# Altium schematic sheet Sheet1.SchDoc(Sheet1)
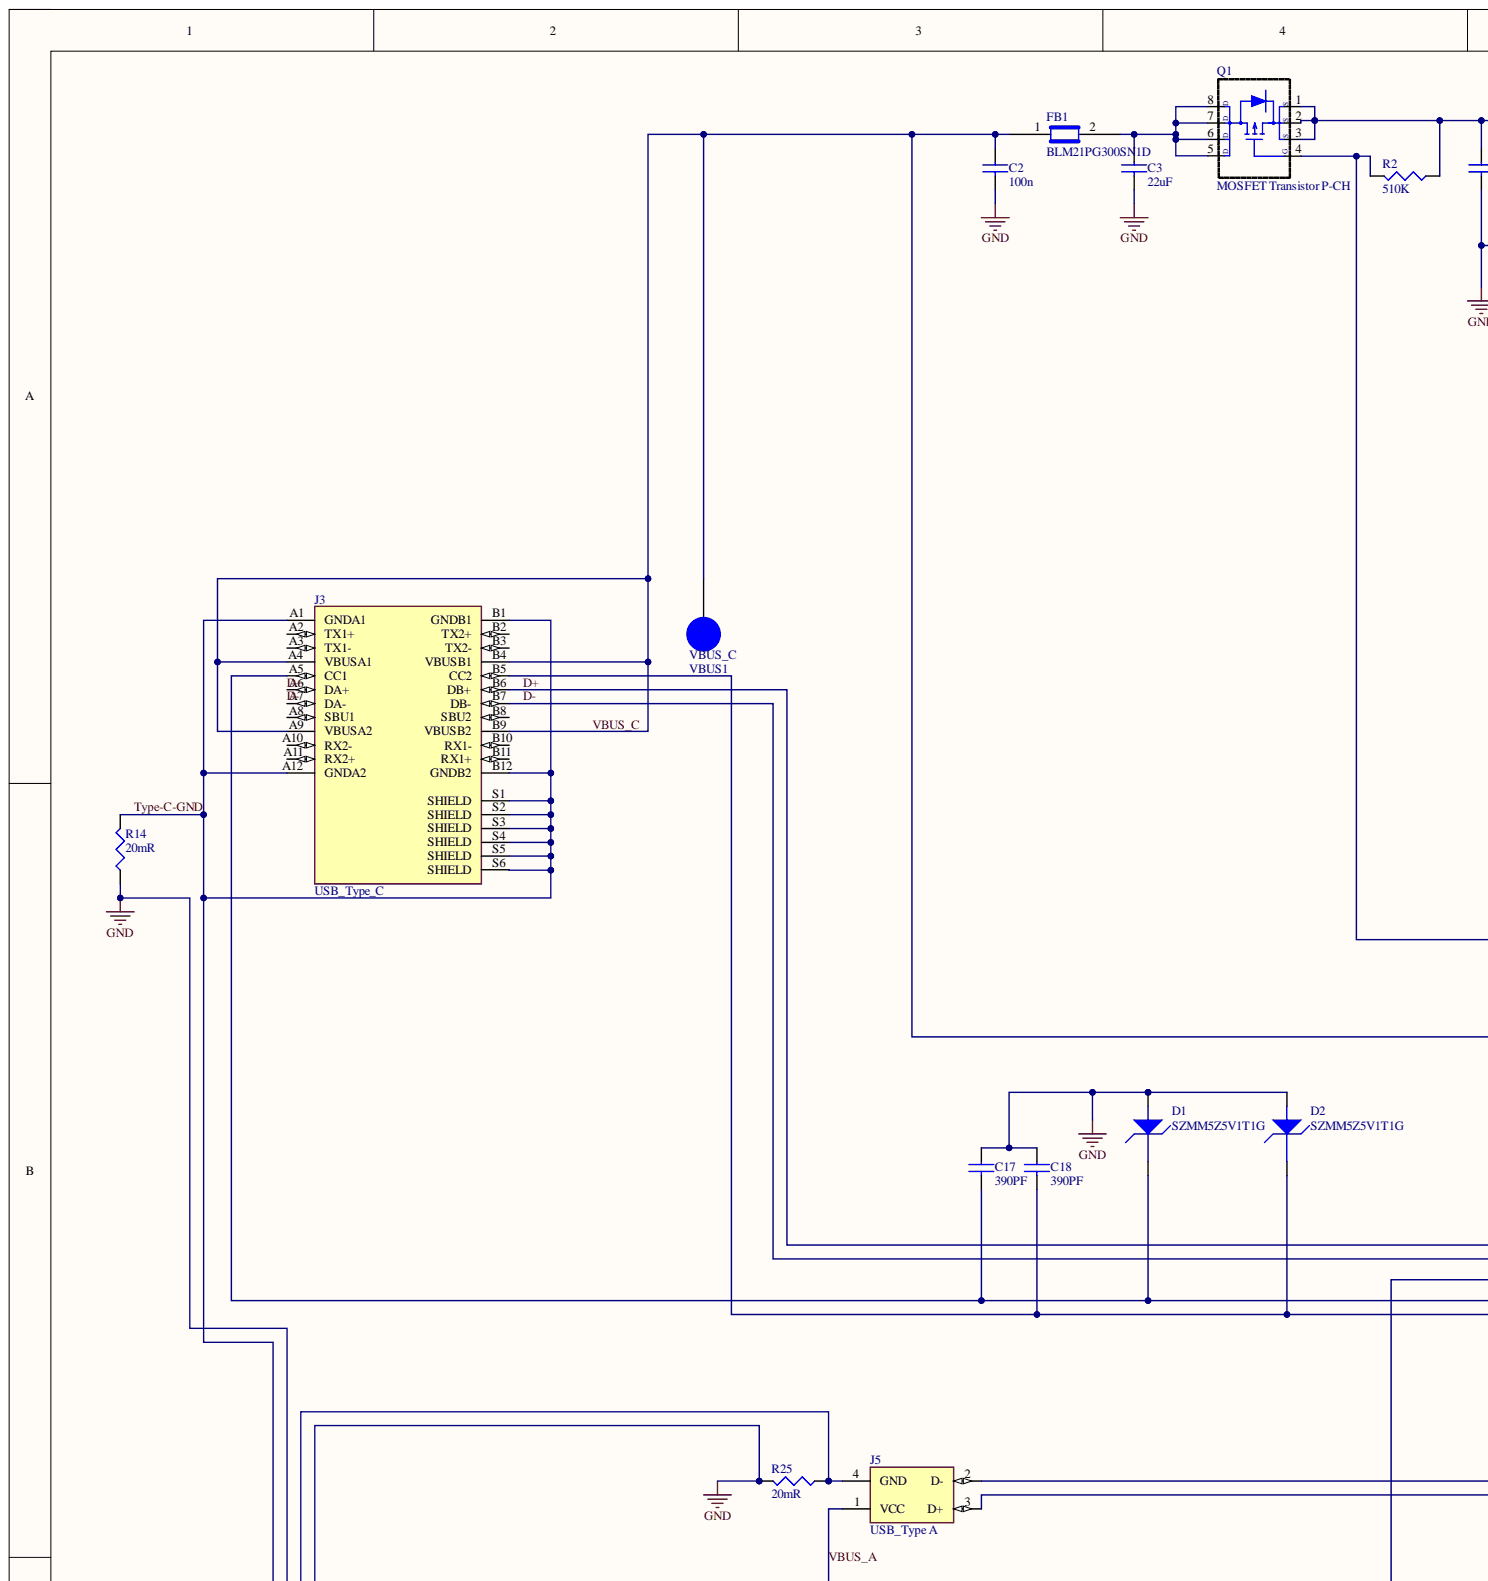
[diagram p0: Sheet1.SchDoc, top left]
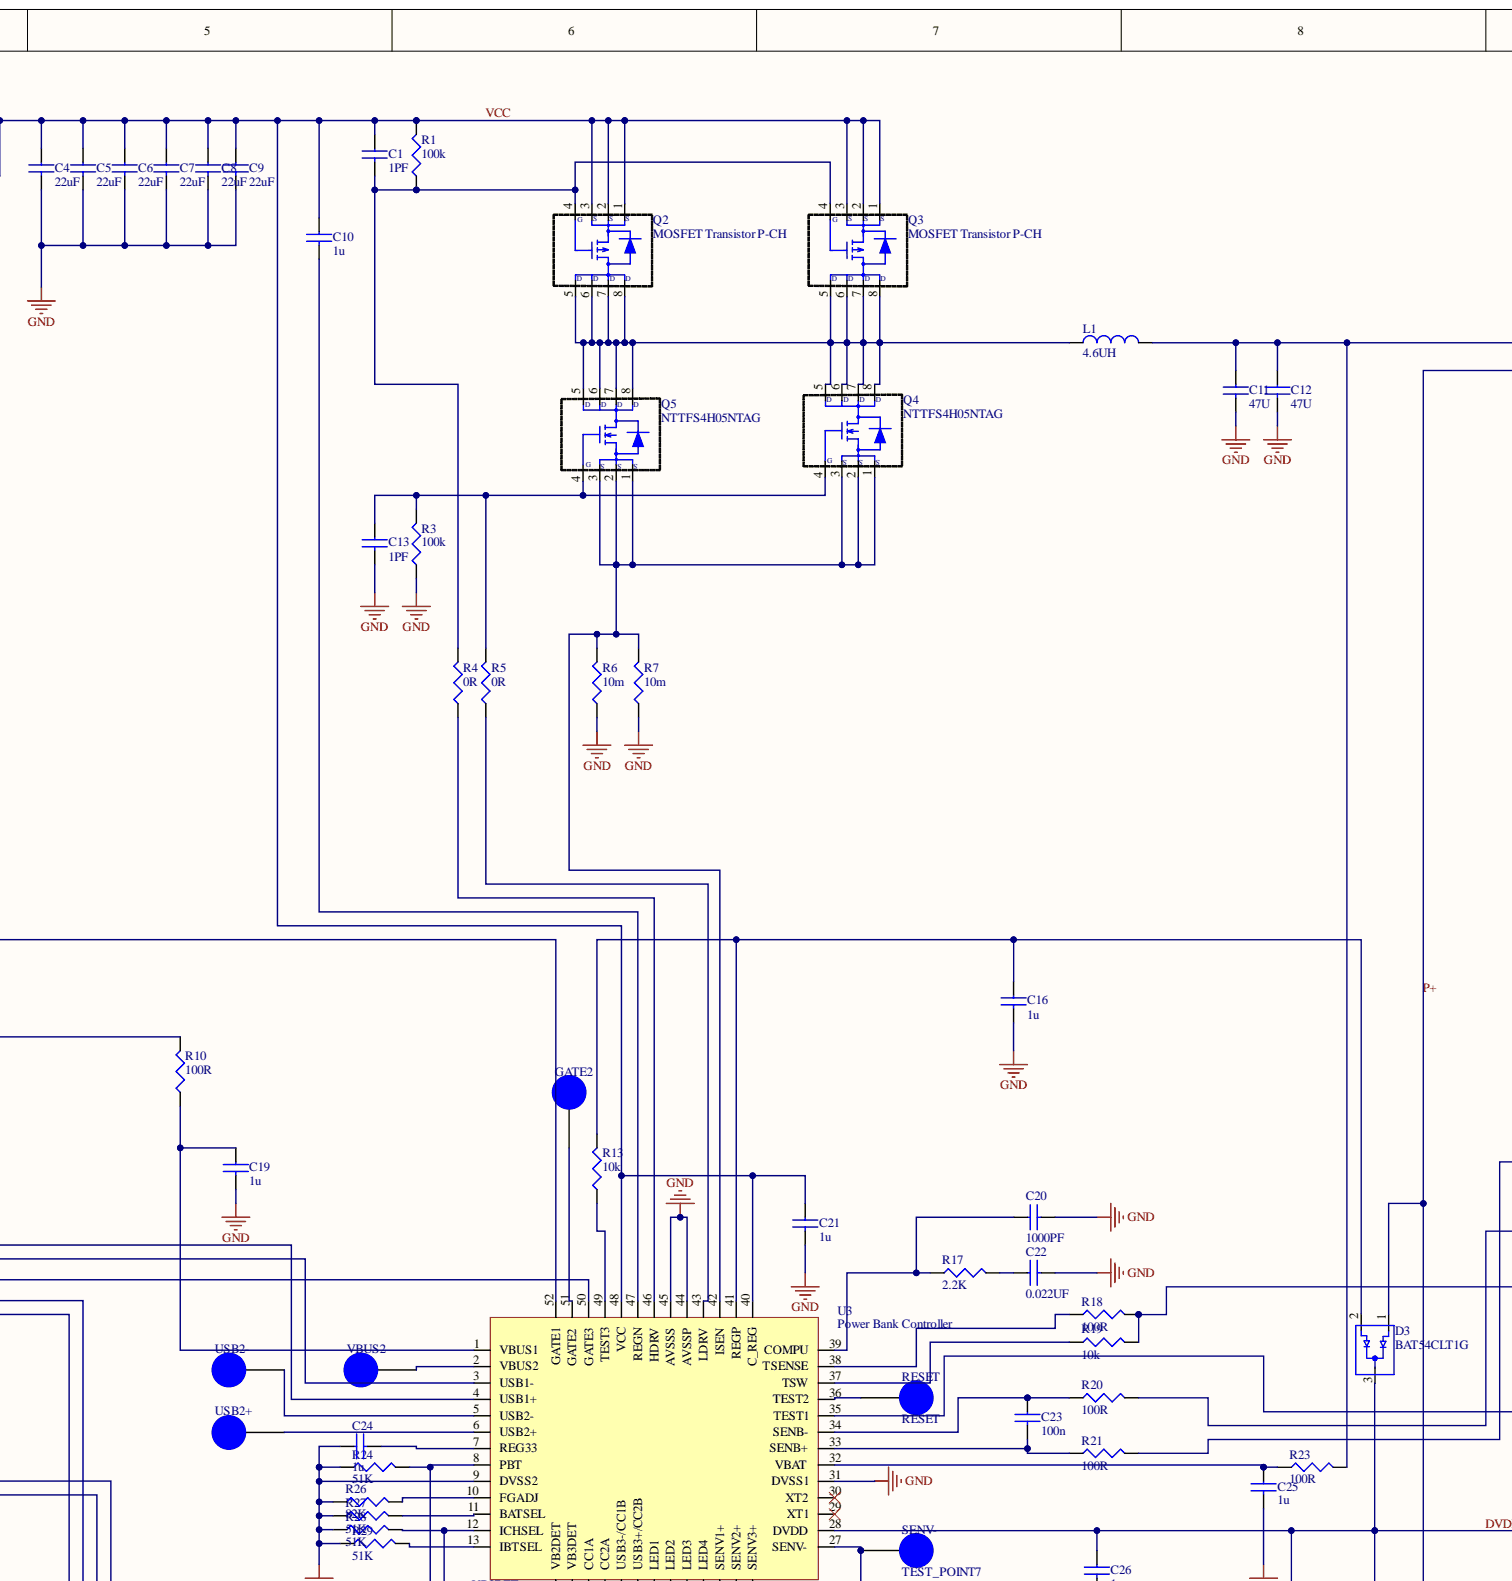
[diagram p1: Sheet1.SchDoc, top centre]
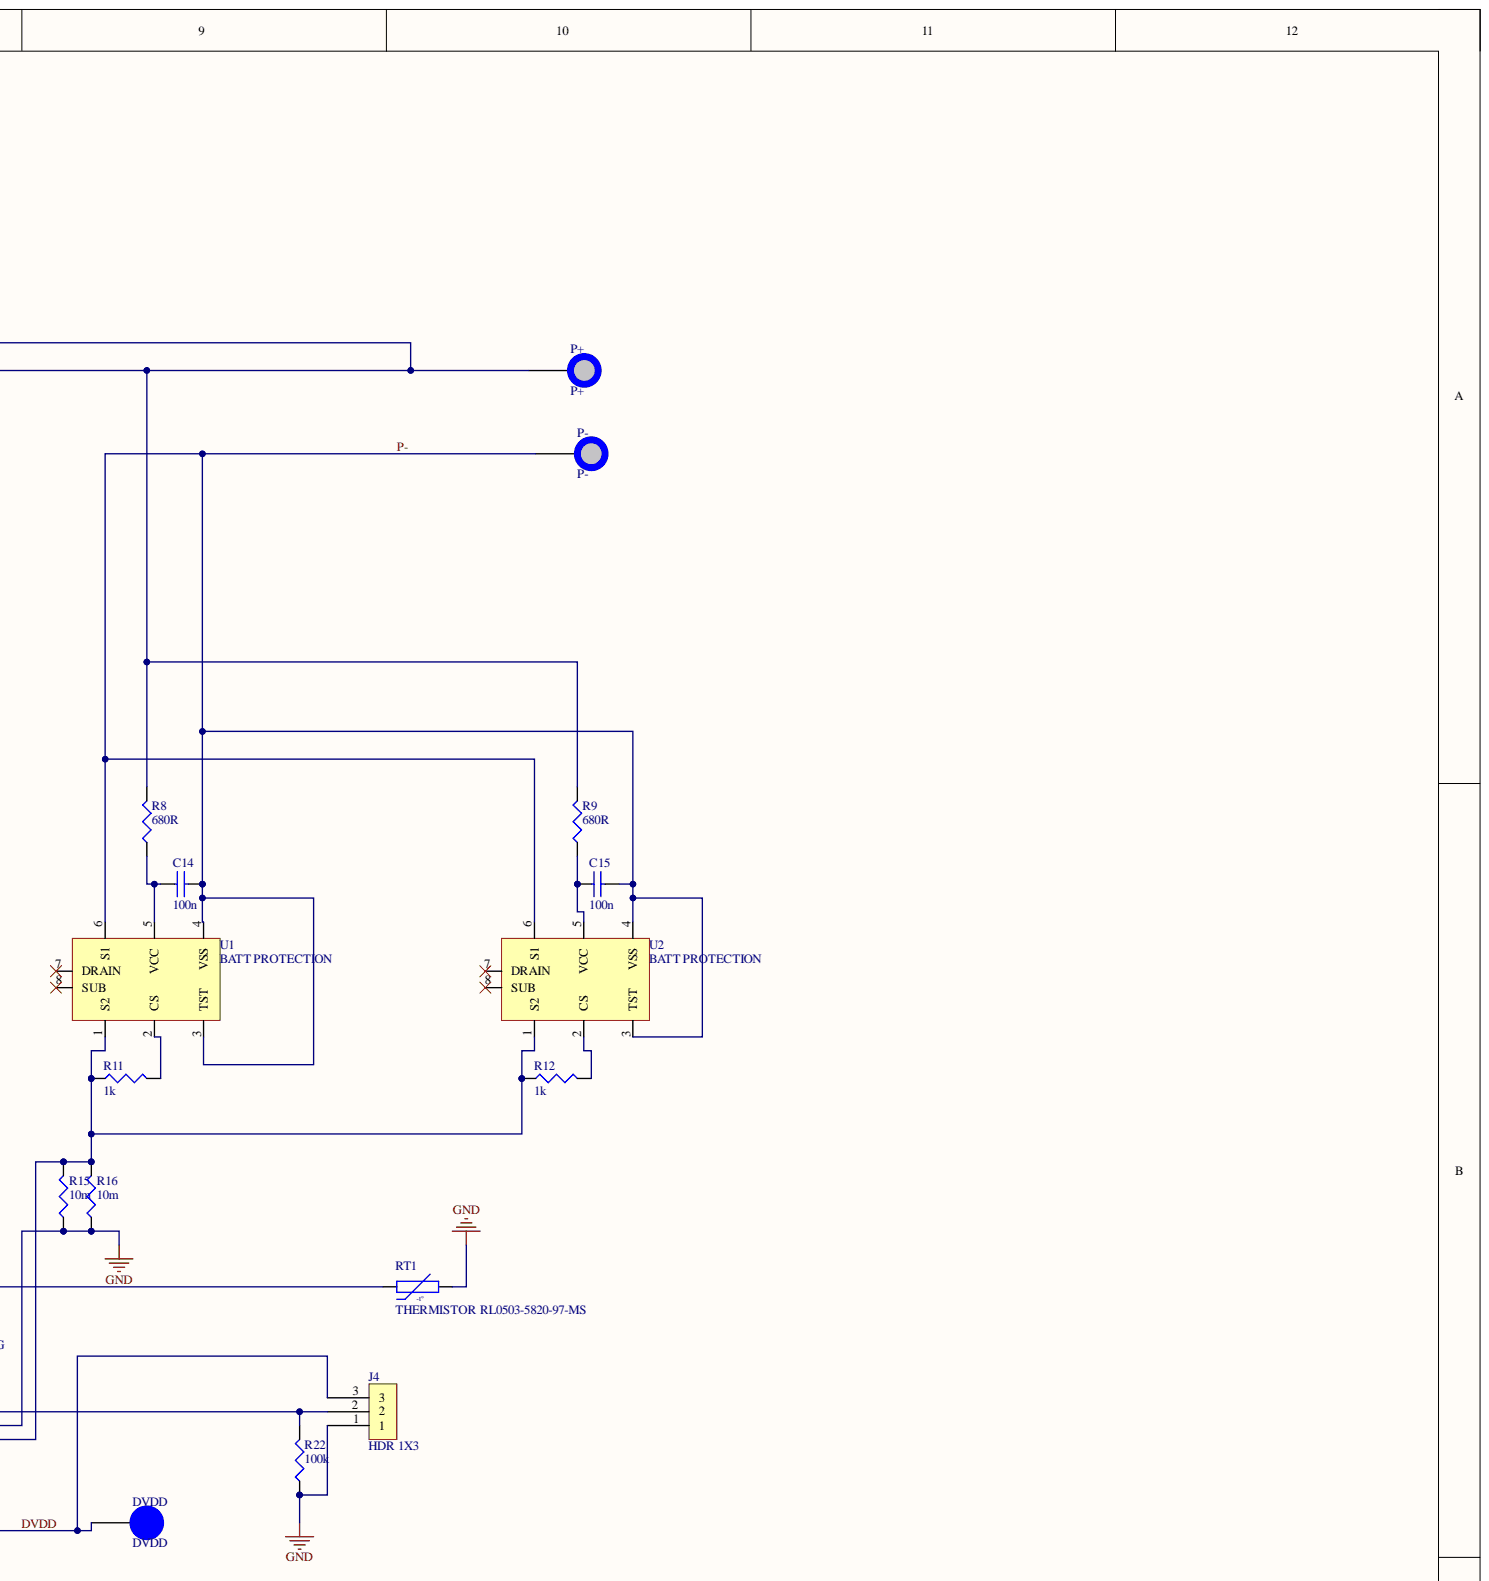
[diagram p2: Sheet1.SchDoc, top right]
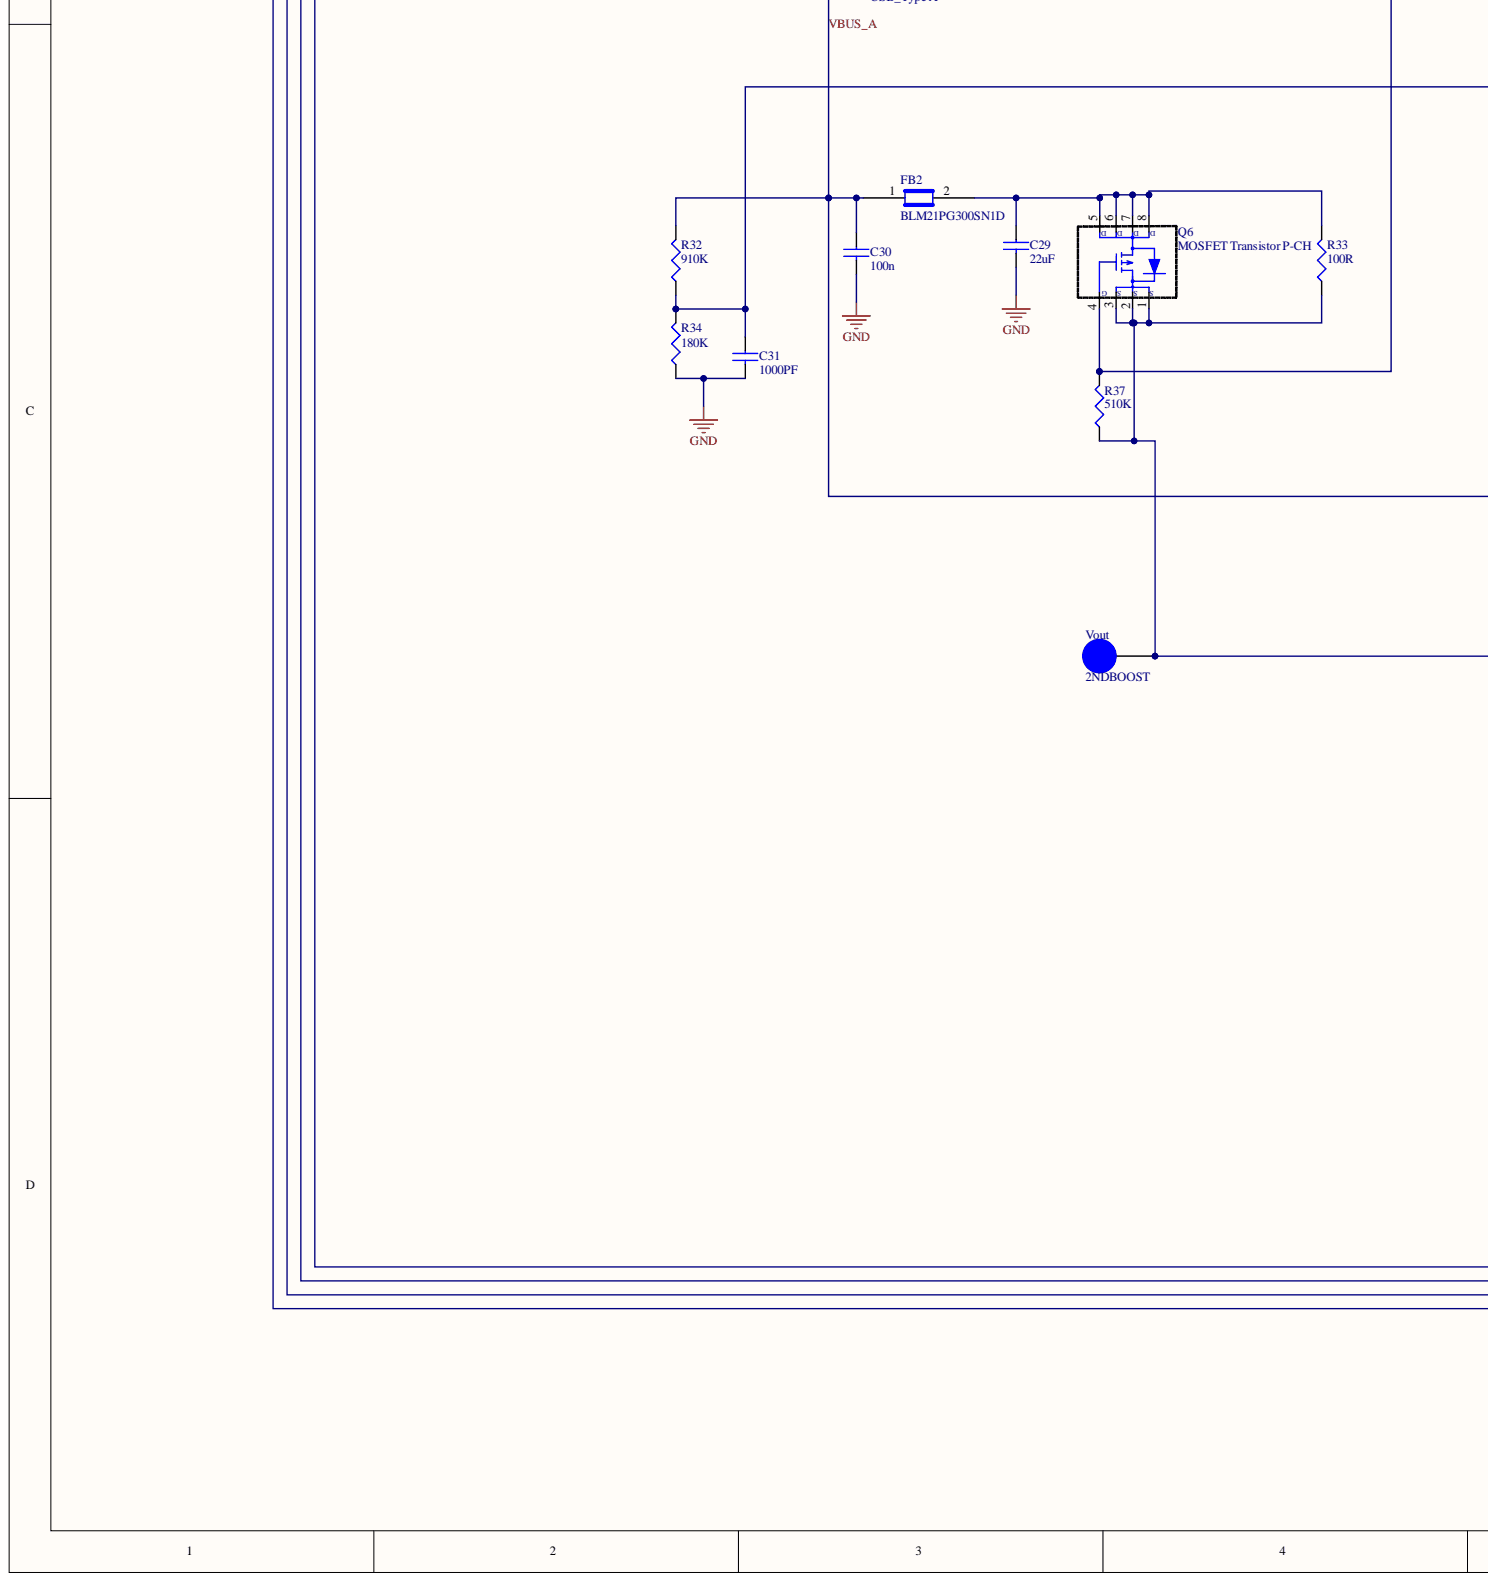
[diagram p3: Sheet1.SchDoc, bottom left]
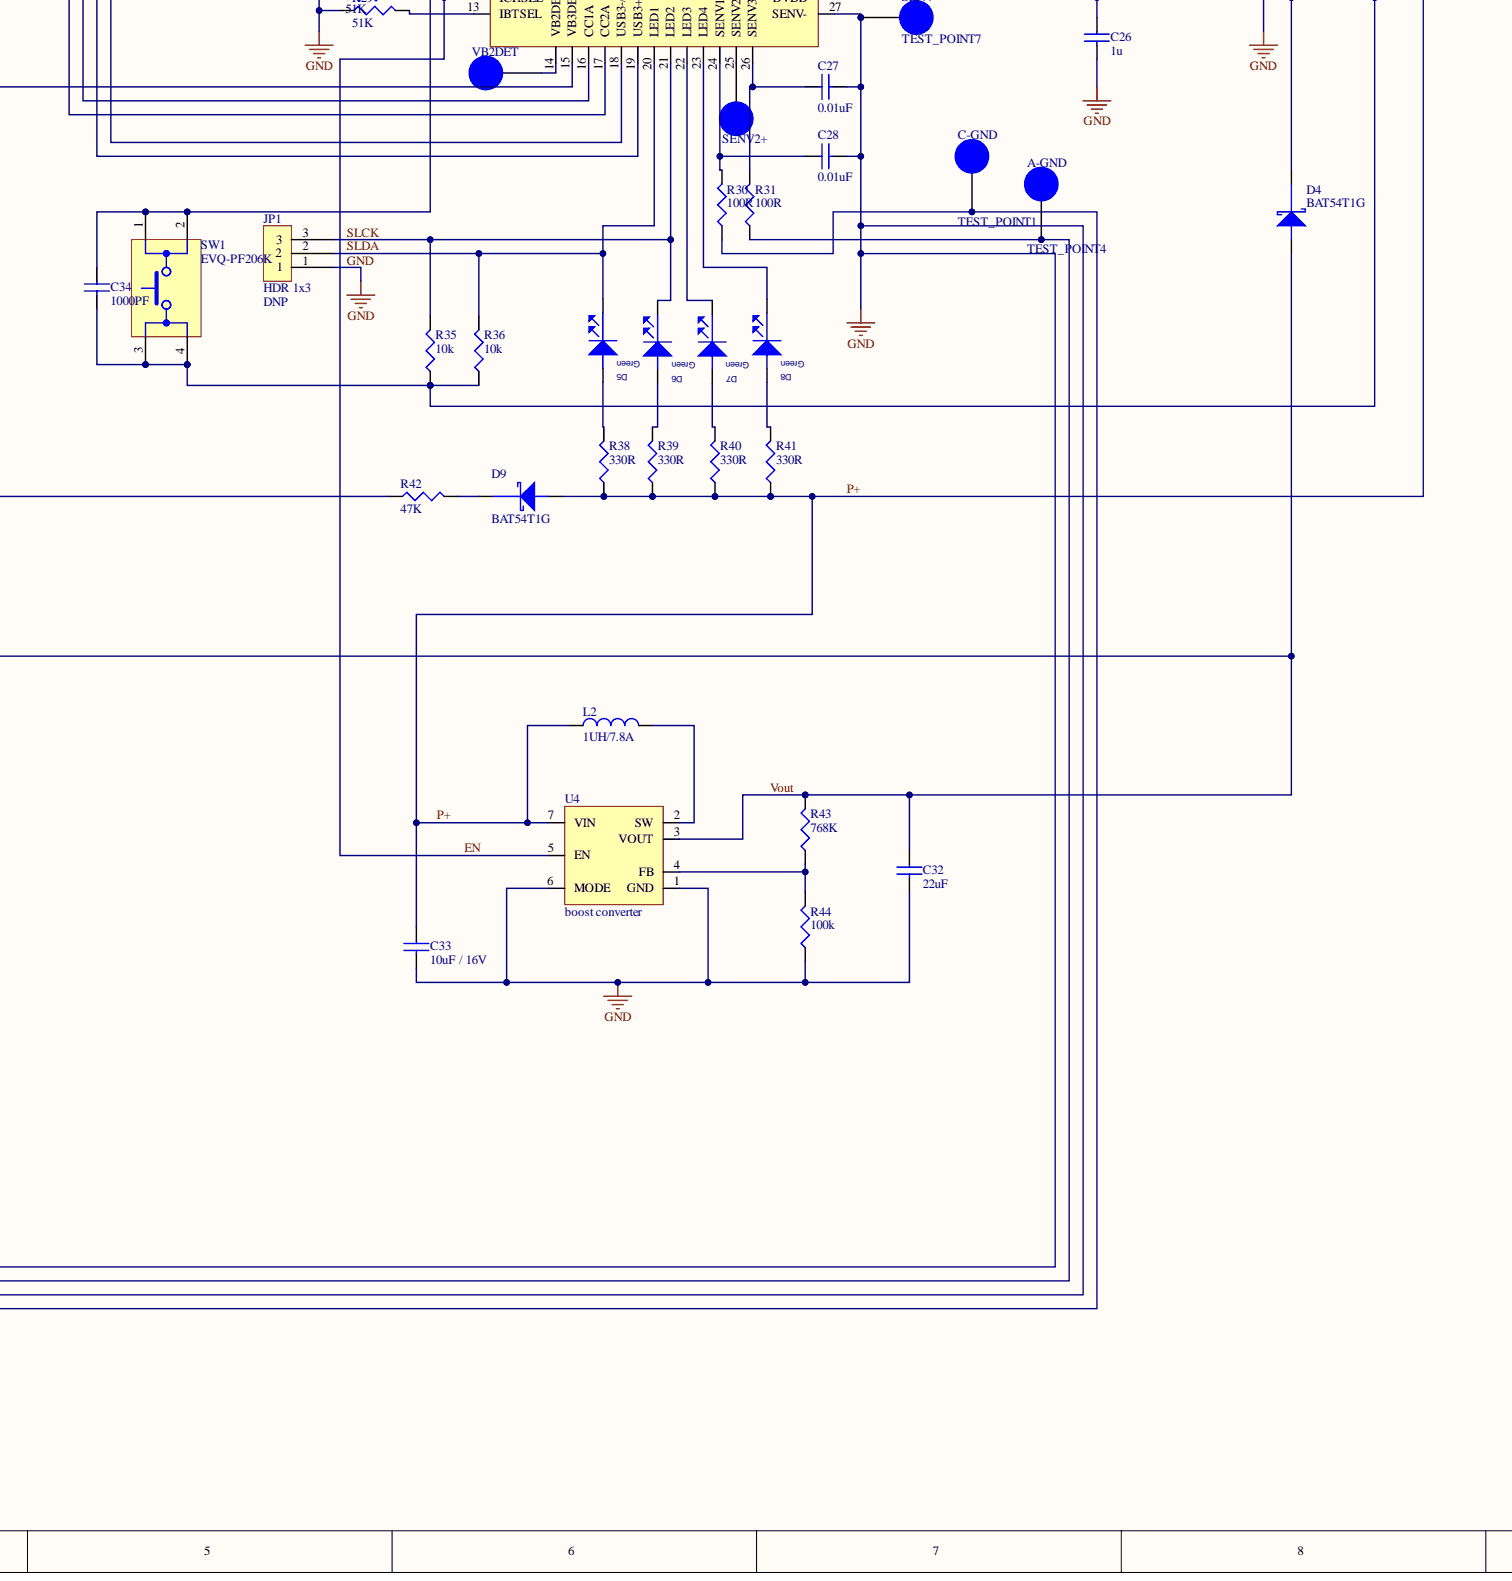
[diagram p4: Sheet1.SchDoc, bottom centre]
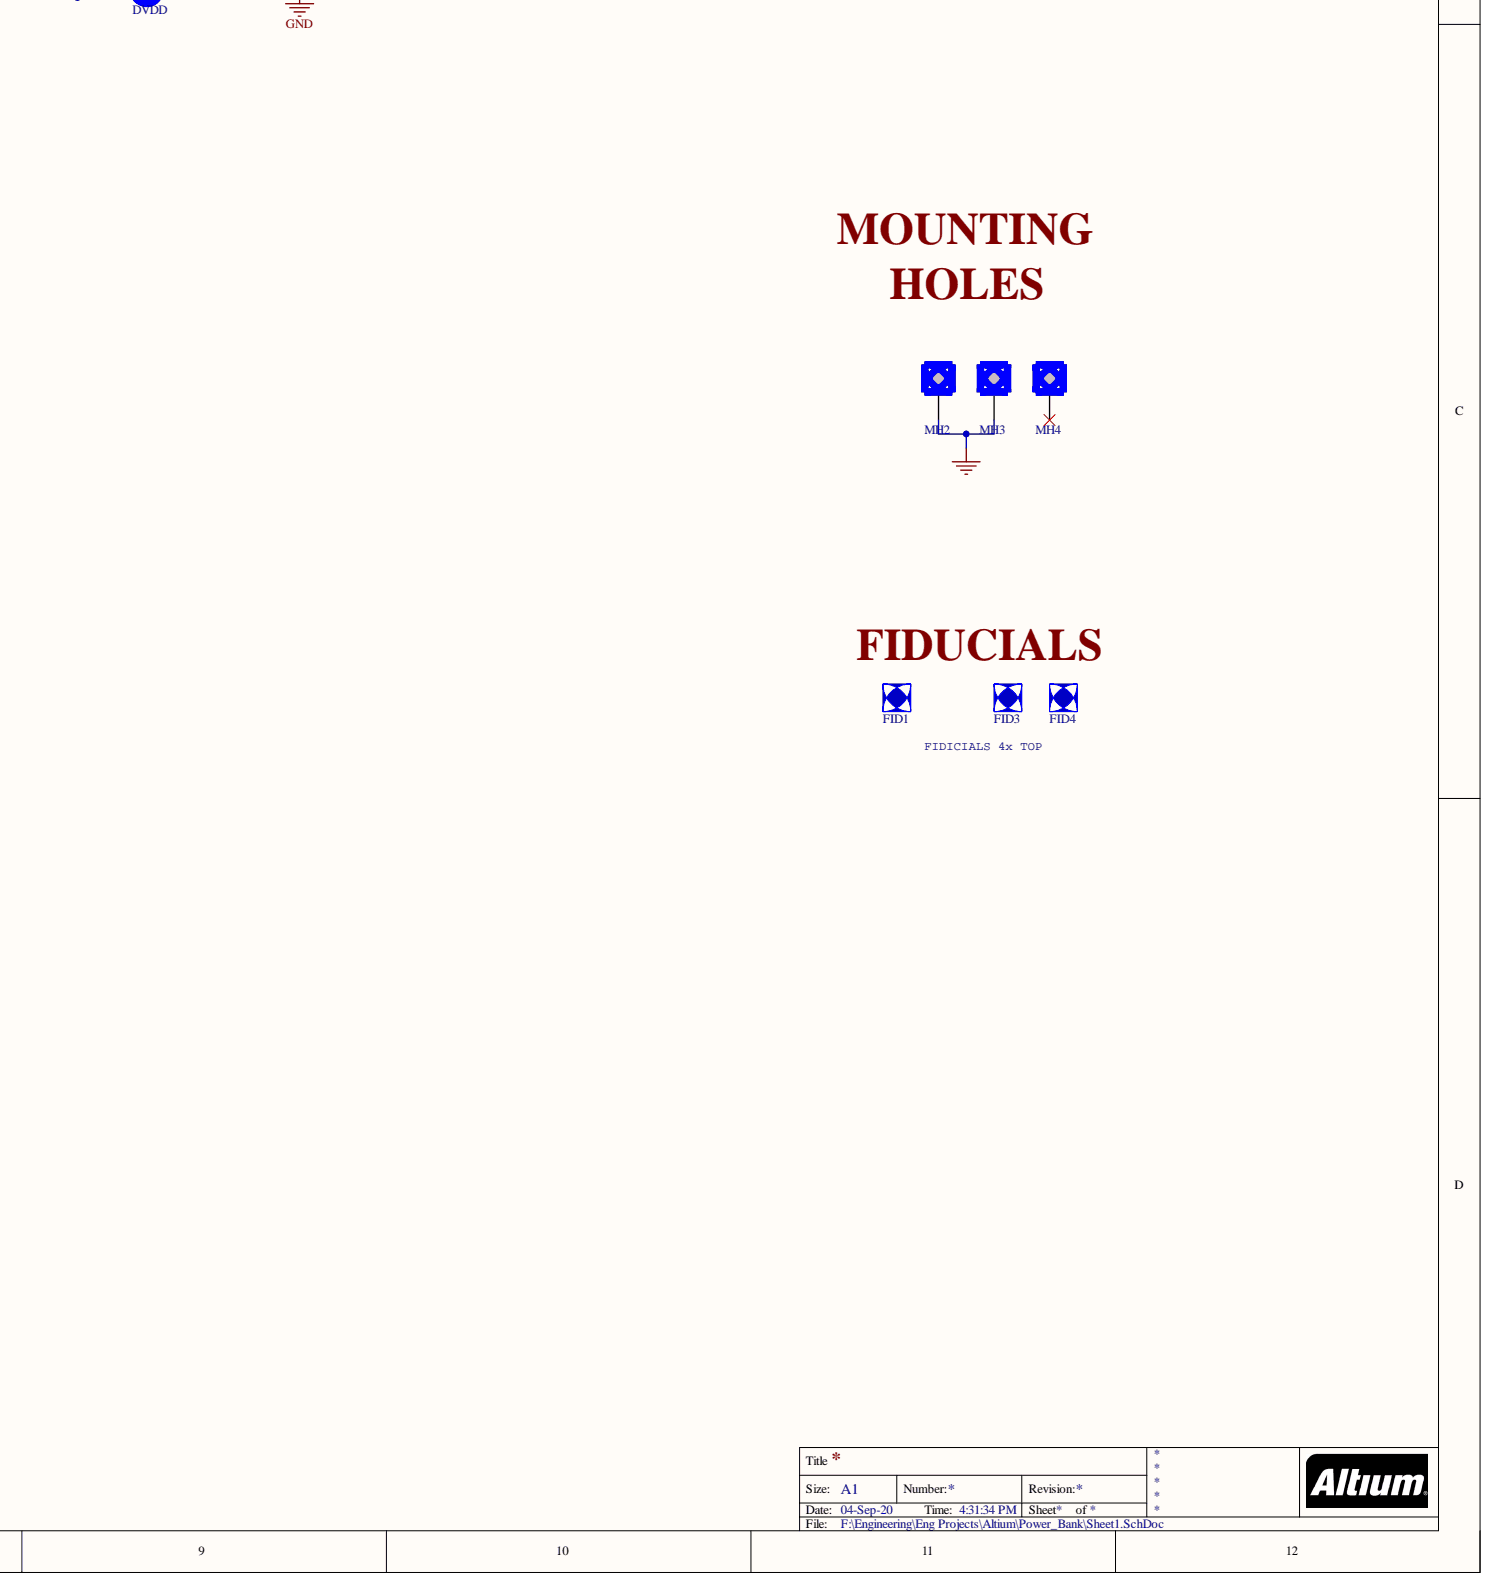
[diagram p5: Sheet1.SchDoc, bottom right]

## Parts
A-GND  TEST_POINT4  [TEST_POINT]
C1  1PF  [C0402]
C2  100n  [C0402]
C3  22uF  [C0402]
C4  22uF  [C0402]
C5  22uF  [C0402]
C6  22uF  [C0402]
C7  22uF  [C0402]
C8  22uF  [C0402]
C9  22uF  [C0402]
C10  1u  [C0402]
C11  47U  [C0603]
C12  47U  [C0603]
C13  1PF  [C0402]
C14  100n  [C0402]
C15  100n  [C0402]
C16  1u  [C0402]
C17  390PF  [C0402]
C18  390PF  [C0402]
C19  1u  [C0402]
C20  1000PF  [C0402]
C21  1u  [C0402]
C22  0.022UF  [C0402]
C23  100n  [C0402]
C24  1u  [C0402]
C25  1u  [C0402]
C26  1u  [C0402]
C27  0.01uF  [C0402]
C28  0.01uF  [C0402]
C29  22uF  [C0402]
C30  100n  [C0402]
C31  1000PF  [C0402]
C32  22uF  [C0402]
C33  10uF / 16V  Voltage - Rated: 16V  [C0402]
C34  1000PF  [C0402]
C-GND  TEST_POINT1  [TEST_POINT]
D1  SZMM5Z5V1T1G  [SOD523]
D2  SZMM5Z5V1T1G  [SOD523]
D3  BAT54CLT1G  [SOT23]
D4  BAT54T1G  [SOD123]
D5  Green  [LED_0603]
D6  Green  [LED_0603]
D7  Green  [LED_0603]
D8  Green  [LED_0603]
D9  BAT54T1G  [SOD123]
DVDD  DVDD  [TEST_POINT]
FB1  BLM21PG300SN1D  [FB0805]
FB2  BLM21PG300SN1D  [FB0805]
FID1  FID_1MMC  [FID_40X120D]  (value not drawn)  (no BOM)
FID3  FID_1MMC  [FID_40X120D]  (value not drawn)  (no BOM)
FID4  FID_1MMC  [FID_40X120D]  (value not drawn)  (no BOM)
GATE2  TEST_POINT8  [TEST_POINT]  (value not drawn)
J3  USB_Type_C  [USB_TYPE_C1]
J4  HDR 1X3  [HDR_1X3_2.5mm]
J5  USB_Type A  [USB_Type_A]
JP1  HDR 1x3  [Hdr_1x3_2.54mm]
L1  4.6UH  [IND_NON_STAND2X5.6_12.8P]
L2  1UH/7.8A  [IND_NON_STAND2.6X8.2_2.8P]
MH2  MH_7.4MMC_3.2MMD  [MH_7.4MMD_3.2MMD]  (value not drawn)  (no BOM)
MH3  MH_7.4MMC_3.2MMD  [MH_7.4MMD_3.2MMD]  (value not drawn)  (no BOM)
MH4  MH_7.4MMNC_3.2MMD  [MH_7.4MMD_3.2MMD_NON_COPPER_NPTH]  (value not drawn)  (no BOM)
P+  P+  [PAD_WITH_4mmX1.5mm]  (no BOM)
P-  P-  [PAD_WITH_4mmX1.5mm]  (no BOM)
Q1  MOSFET Transistor P-CH  [ECHO8310]
Q2  MOSFET Transistor P-CH  [ECHO8310]
Q3  MOSFET Transistor P-CH  [ECHO8310]
Q4  NTTFS4H05NTAG  [WDFN8]
Q5  NTTFS4H05NTAG  [WDFN8]
Q6  MOSFET Transistor P-CH  [ECHO8310]
R1  100k  [R0402]
R2  510K  [R0402]
R3  100k  [R0402]
R4  0R  [R0402]
R5  0R  [R0402]
R6  10m  [R0402]
R7  10m  [R0402]
R8  680R  [R0402]
R9  680R  [R0402]
R10  100R  [R0402]
R11  1k  [R0402]
R12  1k  [R0402]
R13  10k  [R0402]
R14  20mR  [R0402]
R15  10m  [R0402]
R16  10m  [R0402]
R17  2.2K  [R0402]
R18  100R  [R0402]
R19  10k  [R0402]
R20  100R  [R0402]
R21  100R  [R0402]
R22  100k  [R0402]
R23  100R  [R0402]
R24  51K  [R0402]
R25  20mR  [R0402]
R26  82K  [R0402]
R27  51K  [R0402]
R28  51K  [R0402]
R29  51K  [R0402]
R30  100R  [R0402]
R31  100R  [R0402]
R32  910K  [R0402]
R33  100R  [R0402]
R34  180K  [R0402]
R35  10k  [R0402]
R36  10k  [R0402]
R37  510K  [R0402]
R38  330R  [R0402]
R39  330R  [R0402]
R40  330R  [R0402]
R41  330R  [R0402]
R42  47K  [R0402]
R43  768K  [R0402]
R44  100k  [R0402]
RESET  RESET  [TEST_POINT]
RT1  THERMISTOR RL0503-5820-97-MS  [NTC_50]
SENV2+  TEST_POINT2  [TEST_POINT]  (value not drawn)
SENV-  TEST_POINT7  [TEST_POINT]
SW1  EVQ-PF206K  [DIP4_PushButton2]
U1  BATT PROTECTION  [WDFN6 2.6x4.0, 0.65P]
U2  BATT PROTECTION  [WDFN6 2.6x4.0, 0.65P]
U3  Power Bank Controller  [QFN52_6x6_0.4P]
U4  boost converter  [VQFN-HR]
USB2+  TEST_POINT1  [TEST_POINT]
USB2-
VB2DET  TEST_POINT3  [TEST_POINT]  (value not drawn)
VBUS2  TEST_POINT6  [TEST_POINT]  (value not drawn)
VBUS_C  VBUS1  [TEST_POINT]
Vout  2NDBOOST  [TEST_POINT]

## Nets
D+: J3.A6(DA+) J3.B6(DB+) U3.4(USB1+)
D-: J3.A7(DA-) J3.B7(DB-) U3.3(USB1-)
DVDD: C26.1 C34.2 D3.3 D4.1 DVDD.1 J4.3 R35.1 R36.1 SW1.3 SW1.4 U3.28(DVDD)
EN: R28.2 U3.12(ICHSEL) U4.5
GND: C2.2 C3.2 C4.2 C5.2 C6.2 C7.2 C8.2 C9.2 C11.2 C12.2 C13.2 C16.2 C17.1 C18.1 C19.2 C20.2 C21.2 C22.2 C24.1 C25.2 C26.2 C27.2 C28.2 C29.2 C30.2 C31.2 C32.2 C33.2 D1.2 D2.2 J4.1 JP1.1 MH2.1 MH3.1 R3.1 R6.1 R7.1 R14.1 R15.1 R16.1 R20.2 R22.1 R24.1 R25.2 R26.1 R27.1 R28.1 R29.1 R34.1 R44.1 RT1.1 SENV-.1 U3.9(DVSS2) U3.27(SENV-) U3.31(DVSS1) U3.44(AVSSP) U3.45(AVSSS) U4.1 U4.6
P+: C11.1 C12.1 C33.1 D3.1 D9.2 L1.2 L2.1 P+.1 R8.2 R9.2 R23.2 R38.1 R39.1 R40.1 R41.1 U4.7(VIN)
P-: C14.2 C15.2 P-.1 U1.3(TST) U1.4(VSS) U1.6(S1) U2.3(TST) U2.4(VSS) U2.6(S1)
SLCK: D6.1 JP1.3 R35.2 U3.21(LED2)
SLDA: D5.1 JP1.2 R36.2 U3.20(LED1)
Type-C-GND: C-GND.1 J3.A1(GNDA1) J3.A12(GNDA2) J3.B1(GNDB1) J3.B12(GNDB2) J3.S1(SHIELD) J3.S2(SHIELD) J3.S3(SHIELD) J3.S4(SHIELD) J3.S5(SHIELD) J3.S6(SHIELD) R14.2 R30.1
VBUS_A: C30.1 FB2.1 J5.1(VCC) R32.2 R42.1
VBUS_C: C2.1 FB1.1 J3.A4(VBUSA1) J3.A9(VBUSA2) J3.B4(VBUSB1) J3.B9(VBUSB2) R10.2 VBUS_C.1
VCC: C1.1 C4.1 C5.1 C6.1 C7.1 C8.1 C9.1 C10.1 C21.1 Q1.1(S) Q1.2 Q1.3 Q2.1(S) Q2.2(S) Q2.3(S) Q3.1(S) Q3.2 Q3.3(S) R1.2 R2.1 U3.40(C_REG) U3.48(VCC)
Vout: C32.1 D4.2 Q6.1(S) Q6.2(S) Q6.3(S) R33.1 R37.1 R43.2 U4.3(VOUT) Vout.1
NetA-GND_1: A-GND.1 J5.4(GND) R25.1 R31.1
NetC1_2: C1.2 Q2.4 Q3.4(G) R1.1 R4.2
NetC3_1: C3.1 FB1.2 Q1.5 Q1.6 Q1.7 Q1.8
NetC10_2: C10.2 U3.47(REGN)
NetC13_1: C13.1 Q4.4(G) Q5.4(G) R3.2 R5.2
NetC14_1: C14.1 R8.1 U1.5(VCC)
NetC15_1: C15.1 R9.1 U2.5(VCC)
NetC16_1: C16.1 D3.2 R13.2 U3.41(REGP)
NetC17_2: C17.2 D1.1 J3.A5(CC1) U3.16(CC1A)
NetC18_2: C18.2 D2.1 J3.B5(CC2) U3.17(CC2A)
NetC19_1: C19.1 R10.1 U3.1(VBUS1)
NetC20_1: C20.1 R17.1 U3.39(COMPU)
NetC22_1: C22.1 R17.2
NetC23_1: C23.1 R20.1 U3.34(SENB-)
NetC23_2: C23.2 R21.1 U3.33(SENB+)
NetC24_2: C24.2 U3.7(REG33)
NetC25_1: C25.1 R23.1 U3.32(VBAT)
NetC27_1: C27.1 R31.2 U3.26(SENV3+)
NetC28_1: C28.1 R30.2 U3.24(SENV1+)
NetC29_1: C29.1 FB2.2 Q6.5(D) Q6.6(D) Q6.7(D) Q6.8(D) R33.2
NetC31_1: C31.1 R32.1 R34.2 U3.15(VB3DET)
NetC34_1: C34.1 R24.2 SW1.1 SW1.2 U3.8(PBT)
NetD5_2: D5.2 R38.2
NetD6_2: D6.2 R39.2
NetD7_1: D7.1 U3.22(LED3)
NetD7_2: D7.2 R40.2
NetD8_1: D8.1 U3.23(LED4)
NetD8_2: D8.2 R41.2
NetD9_1: D9.1 R42.2
NetGATE2_1: GATE2.1 U3.51(GATE2)
NetJ4_2: J4.2 R22.2 U3.35(TEST1)
NetJ5_2: J5.2(D-) U3.18(USB3-/CC1B)
NetJ5_3: J5.3(D+) U3.19(USB3+/CC2B)
NetL1_1: L1.1 Q2.5 Q2.6(D) Q2.7(D) Q2.8(D) Q3.5(D) Q3.6(D) Q3.7 Q3.8 Q4.5(D) Q4.6(D) Q4.7(D) Q4.8(D) Q5.5(D) Q5.6(D) Q5.7 Q5.8
NetL2_2: L2.2 U4.2(SW)
NetQ1_4: Q1.4 R2.2 U3.52(GATE1)
NetQ4_1: Q4.1(S) Q4.2(S) Q4.3(S) Q5.1 Q5.2 Q5.3(S) R6.2 R7.2 U3.42(ISEN)
NetQ6_4: Q6.4 R37.2 U3.50(GATE3)
NetR4_1: R4.1 U3.46(HDRV)
NetR5_1: R5.1 U3.43(LDRV)
NetR11_1: R11.1 R12.1 R15.2 R16.2 R21.2 U1.1(S2) U2.1(S2)
NetR11_2: R11.2 U1.2(CS)
NetR12_2: R12.2 U2.2(CS)
NetR13_1: R13.1 U3.49(TEST3)
NetR18_1: R18.1 U3.38(TSENSE)
NetR18_2: R18.2 R19.2 RT1.2
NetR19_1: R19.1 U3.37(TSW)
NetR26_2: R26.2 U3.10(FGADJ)
NetR27_2: R27.2 U3.11(BATSEL)
NetR29_2: R29.2 U3.13(IBTSEL)
NetR43_1: R43.1 R44.2 U4.4
NetRESET_1: RESET.1 U3.36(TEST2)
NetSENV2+_1: SENV2+.1 U3.25(SENV2+)
NetU3_2: U3.2(VBUS2) VBUS2.1
NetU3_5: U3.5(USB2-) USB2-.1
NetU3_6: U3.6(USB2+) USB2+.1
NetU3_14: U3.14(VB2DET) VB2DET.1

Not connected: J3 A2(TX1+) A3(TX1-) A8(SBU1) A10(RX2-) A11(RX2+) B2(TX2+) B3(TX2-) B8(SBU2) B10(RX1-) B11(RX1+); MH4 1; U1 7(DRAIN) 8(SUB); U2 7(DRAIN) 8(SUB); U3 29(XT1) 30(XT2)
